# Altium schematic sheet Interfaces.SchDoc(Interfaces)
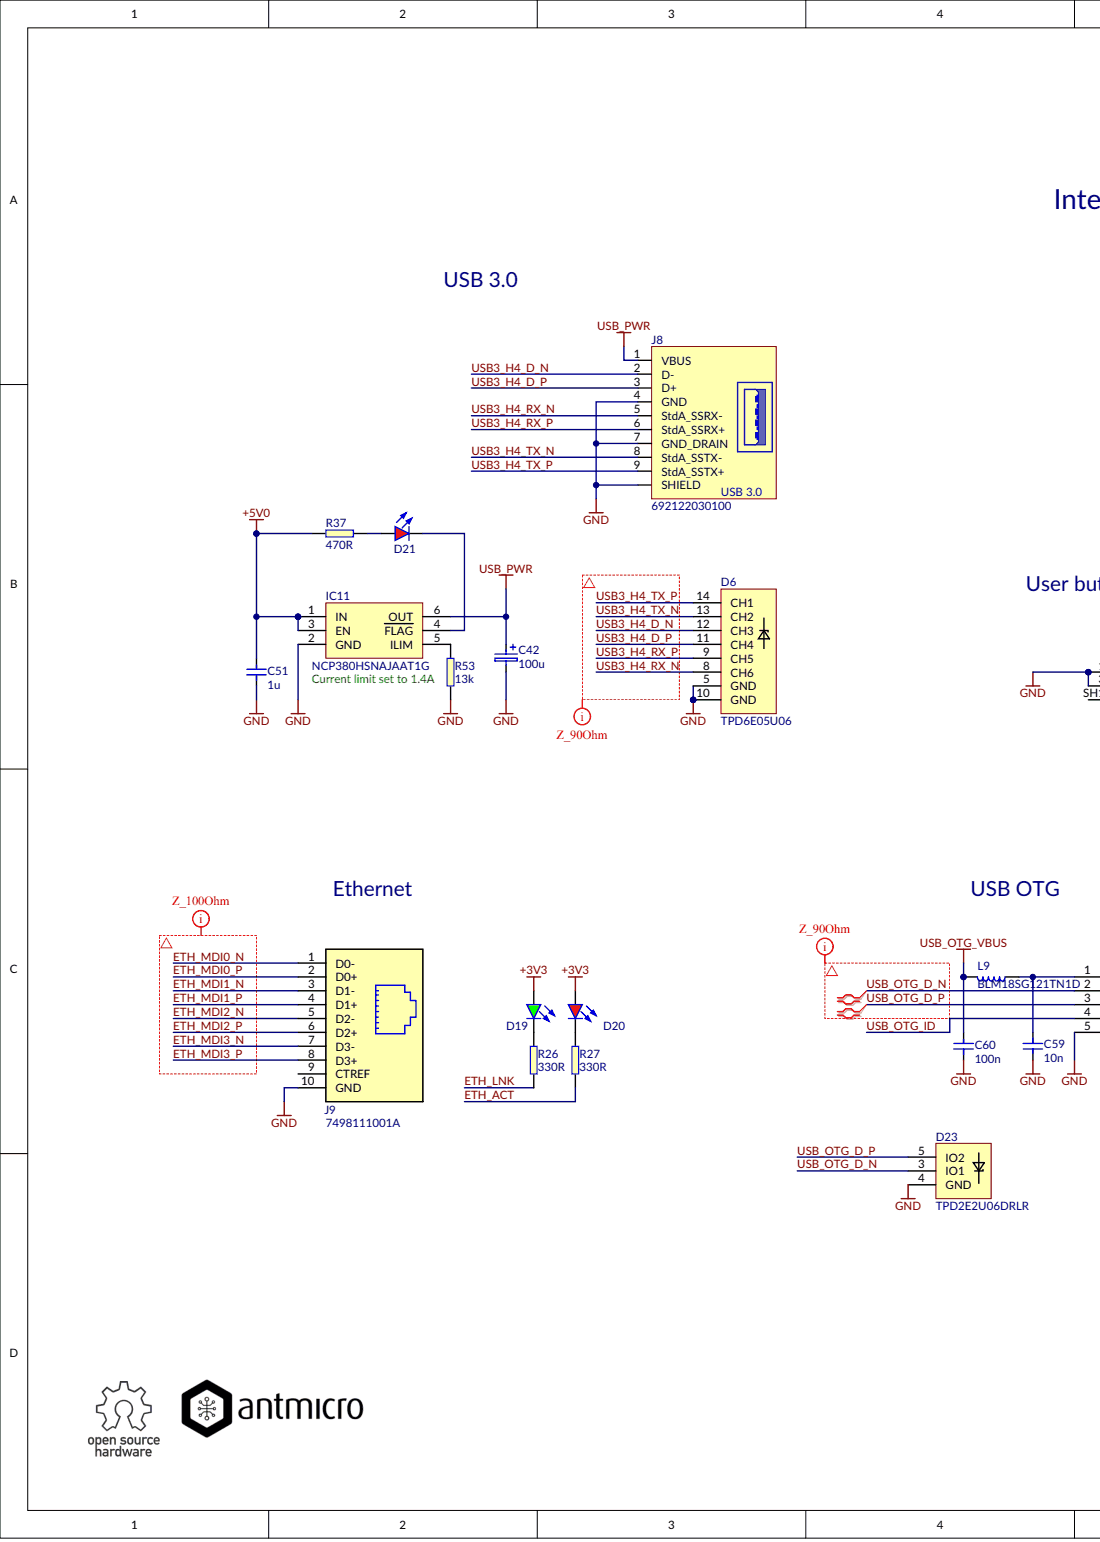
[diagram p0: Interfaces.SchDoc, left]
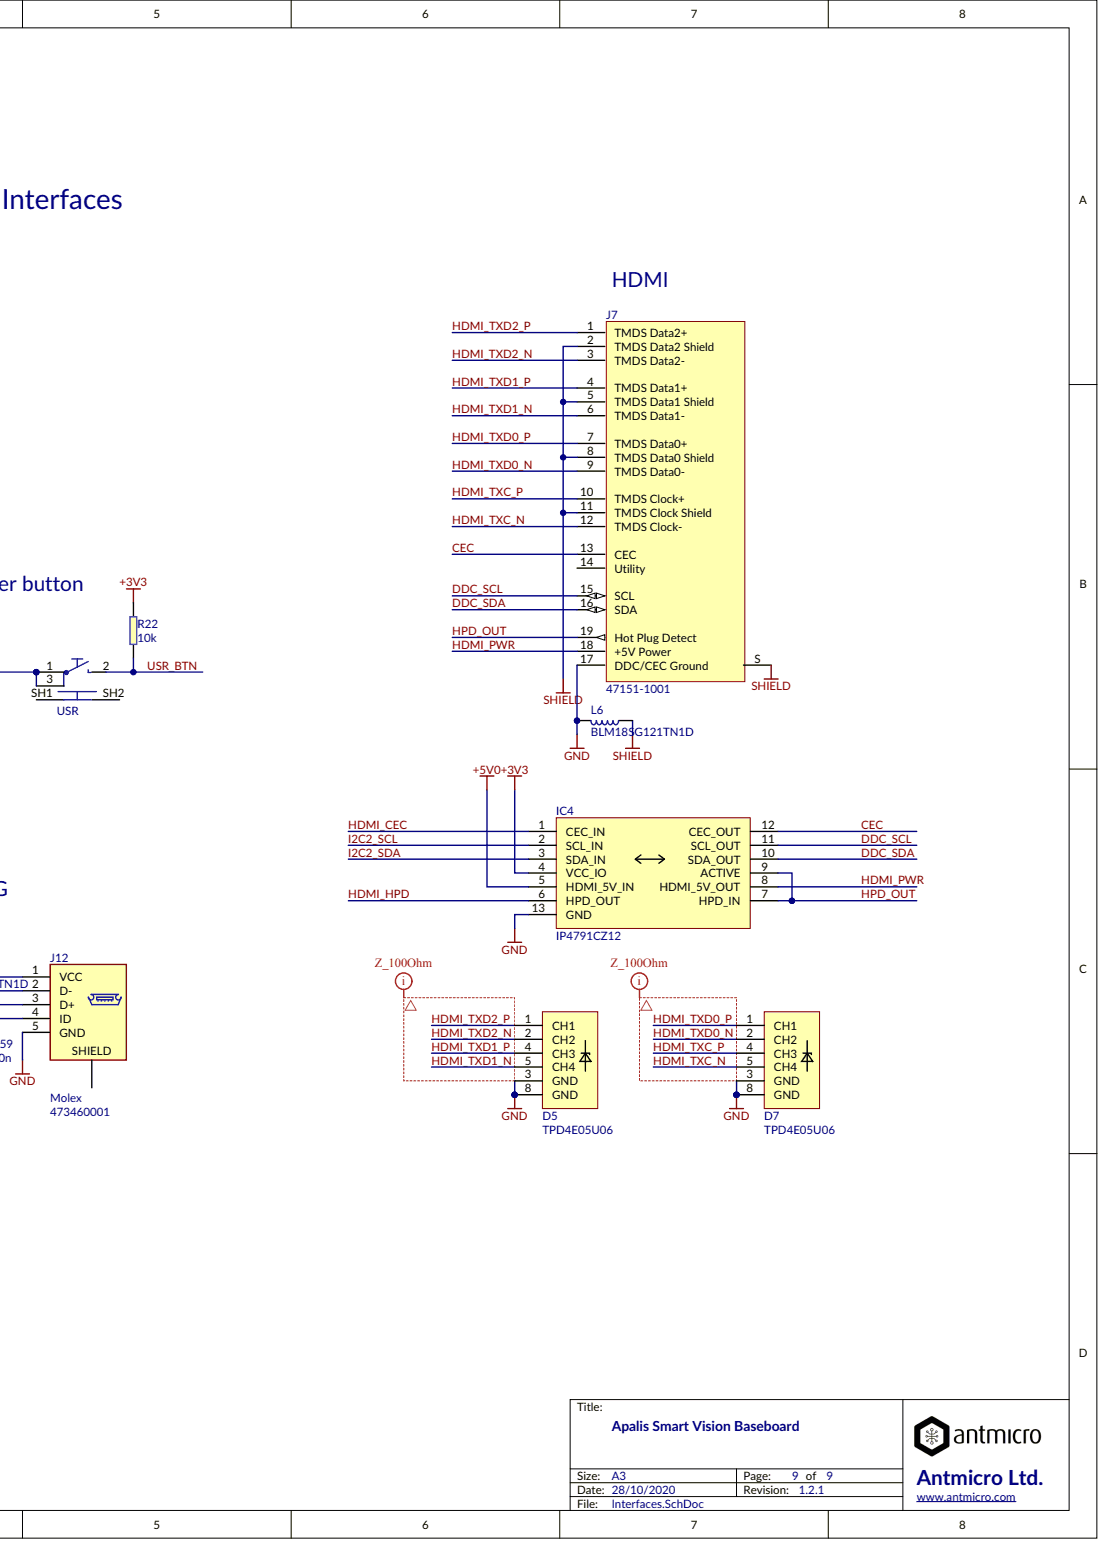
[diagram p1: Interfaces.SchDoc, right]

## Parts
C42  100u
C51  1u
C59  10n
C60  100n
D5  TPD4E05U06
D6  TPD6E05U06
D7  TPD4E05U06
D19  KPJA-2107CKCK  (value not drawn)
D20  KPJA-2107SYCK  (value not drawn)
D21  KPT-1608EC  (value not drawn)
D23  TPD2E2U06DRLR
IC4  IP4791CZ12
IC11  NCP380HSNAJAAT1G
J7  47151-1001
J8  692122030100
J9  7498111001A
J12  Manufacturer: Molex  Part Symbol: 473460001  (hidden comment: Molex 47346-0001)
L6  BLM18SG121TN1D
L9  BLM18SG121TN1D
R22  10k
R26  330R
R27  330R
R37  470R
R53  13k
USR  TL3340AF160QG  (value not drawn)

## Nets
+3V3: D19.A D20.A IC4.4(VCC_IO) R22.2
+5V0: C51.2 IC4.5(HDMI_5V_IN) IC11.1(IN) IC11.3(EN) R37.2
GND: C42.2 C51.1 C59.2 C60.1 D5.3(GND) D5.8(GND) D6.5(GND) D6.10(GND) D7.3(GND) D7.8(GND) D23.4 IC4.13(GND) IC11.2(GND) J7.17 J8.4(GND) J8.7(GND_DRAIN) J8.SHIELD J9.10(GND) J12.5(GND) L6.1 R53.1 USR.1 USR.3
SHIELD: J7.2(TMDS_Data2_Shield) J7.5(TMDS_Data1_Shield) J7.8(TMDS_Data0_Shield) J7.11(TMDS_Clock_Shield) J7.S L6.2
USB_OTG_VBUS: C60.2 L9.1
USB_PWR: C42.1 IC11.6(OUT) J8.1(VBUS)
CEC: IC4.12(CEC_OUT) J7.13(CEC)
DDC_SCL: IC4.11(SCL_OUT) J7.15(SCL)
DDC_SDA: IC4.10(SDA_OUT) J7.16(SDA)
ETH_ACT: R27.2
ETH_LNK: R26.2
ETH_MDI0_N: J9.1(D0-)
ETH_MDI0_P: J9.2(D0+)
ETH_MDI1_N: J9.3(D1-)
ETH_MDI1_P: J9.4(D1+)
ETH_MDI2_N: J9.5(D2-)
ETH_MDI2_P: J9.6(D2+)
ETH_MDI3_N: J9.7(D3-)
ETH_MDI3_P: J9.8(D3+)
HDMI_CEC: IC4.1(CEC_IN)
HDMI_HPD: IC4.6(HPD_OUT)
HDMI_PWR: IC4.8(HDMI_5V_OUT) J7.18(+5V_Power)
HDMI_TXC_N: D7.5(CH4) J7.12(TMDS_Clock-)
HDMI_TXC_P: D7.4(CH3) J7.10(TMDS_Clock+)
HDMI_TXD0_N: D7.2(CH2) J7.9(TMDS_Data0-)
HDMI_TXD0_P: D7.1(CH1) J7.7(TMDS_Data0+)
HDMI_TXD1_N: D5.5(CH4) J7.6(TMDS_Data1-)
HDMI_TXD1_P: D5.4(CH3) J7.4(TMDS_Data1+)
HDMI_TXD2_N: D5.2(CH2) J7.3(TMDS_Data2-)
HDMI_TXD2_P: D5.1(CH1) J7.1(TMDS_Data2+)
HPD_OUT: IC4.7(HPD_IN) IC4.9(ACTIVE) J7.19(Hot_Plug_Detect)
I2C2_SCL: IC4.2(SCL_IN)
I2C2_SDA: IC4.3(SDA_IN)
USB3_H4_D_N: D6.12(CH3) J8.2(D-)
USB3_H4_D_P: D6.11(CH4) J8.3(D+)
USB3_H4_RX_N: D6.8(CH6) J8.5(StdA_SSRX-)
USB3_H4_RX_P: D6.9(CH5) J8.6(StdA_SSRX+)
USB3_H4_TX_N: D6.13(CH2) J8.8(StdA_SSTX-)
USB3_H4_TX_P: D6.14(CH1) J8.9(StdA_SSTX+)
USB_OTG_D_N: D23.3 J12.2(D-)
USB_OTG_D_P: D23.5 J12.3(D+)
USB_OTG_ID: J12.4(ID)
USR_BTN: R22.1 USR.2
NetC59_1: C59.1 J12.1(VCC) L9.2
NetD19_K: D19.K R26.1
NetD20_K: D20.K R27.1
NetD21_A: D21.A R37.1
NetD21_K: D21.K IC11.4(FLAG)
NetIC11_5: IC11.5(ILIM) R53.2
N00141:
N00142:
N00143:
N00144:
N00145:

Not connected: J7 14(Utility); J9 9(CTREF); J12 S; USR SH1 SH2

## Library data of the parts
C42 = (no symbol name): Part Number: C_100u_KEMET_A; Manufacturer: VISHAY; Part Symbol: 298D107X06R3A2T; Value: 100u; MPN: 298D107X06R3A2T
C51 = (no symbol name): Manufacturer: MULTICOMP; Part Number: C_1u_0402; Part Symbol: MC0402F105Z6R3CT; Value: 1u; MPN: MC0402F105Z6R3CT
C59 = (no symbol name): Manufacturer: AVX; Part Number: C_10n_0402; Part Symbol: 04025G103ZAT2A; Value: 10n; MPN: 04025G103ZAT2A
C60 = (no symbol name): Manufacturer: TDK; Part Number: C_100n_0402; Part Symbol: C1005X5R0J104K050BA; Value: 100n; MPN: C1005X5R0J104K050BA
D5 D7 = (no symbol name): Part Number: TPD4E05U06_PASS; Manufacturer: Texas Instruments; Part Symbol: TPD4E05U06DQAR; Value: TPD4E05U06; MPN: TPD4E05U06DQAR
D6 = (no symbol name): Part Number: TPD6E05U06_PASS; Manufacturer: Texas Instruments; Part Symbol: TPD6E05U06RVZR; Value: TPD6E05U06; MPN: TPD6E05U06RVZR
D19 = (no symbol name): Part Number: LED_G; Manufacturer: KINGBRIGHT; Value: KPJA-2107CKCK; Part Symbol: KPJA-2107CKCK; MPN: KPJA-2107CKCK
D20 D21 = (no symbol name): Part Number: LED_R; Manufacturer: KINGBRIGHT
  D20: Value: KPJA-2107SYCK; Part Symbol: KPJA-2107SYCK; MPN: KPJA-2107SYCK
  D21: Value: KPT-1608EC; Part Symbol: KPT-1608EC; MPN: KPT-1608EC
D23 = (no symbol name): Manufacturer: Texas Instruments; Part Number: TPD2E2U06; Value: TPD2E2U06DRLR; Part Symbol: TPD2E2U06DRLR; MPN: TPD2E2U06DRLR
IC4 = (no symbol name): Manufacturer: NXP; Part Number: IP4791CZ12; Value: IP4791CZ12; Part Symbol: IP4791CZ12; MPN: IP4791CZ12
IC11 = (no symbol name): Manufacturer: ON Semiconductor; Part Symbol: NCP380HSNAJAAT1G; Value: NCP380HSNAJAAT1G; Part Number: NCP380HSNAJAAT1G; MPN: NCP380HSNAJAAT1G
J7 = (no symbol name): Part Number: CON-Molex-471511001; Manufacturer: MOLEX; Value: 47151-1001; Part Symbol: 47151-1001; MPN: 47151-1001
J8 = (no symbol name): Manufacturer: WURTH ELEKTRONIK; Part Number: WE-692-122-030-100; Value: 692122030100; Part Symbol: 692122030100; MPN: 692122030100
J9 = (no symbol name): Manufacturer: WURTH ELEKTRONIK; Part Number: WE-749-811-100-1A; Value: 7498111001A; Part Symbol: 7498111001A; MPN: 7498111001A
J12 = (no symbol name): Part Number: CON-Molex-47346-0001; Value: Molex 47346-0001; MPN: 473460001
L6 L9 = (no symbol name): Manufacturer: MURATA; Part Number: BLM18SG121TN1D; Value: BLM18SG121TN1D; Part Symbol: BLM18SG121TN1D; MPN: BLM18SG121TN1D
R22 = (no symbol name): Manufacturer: MULTICOMP; Part Number: R_10k_0402; Part Symbol: MCMR04X1002FTL; Value: 10k; MPN: MCMR04X1002FTL
R26 R27 = (no symbol name): Manufacturer: MULTICOMP; Part Number: R_330R_0402; Part Symbol: MCMR04X3300FTL; Value: 330R; MPN: MCMR04X3300FTL
R37 = (no symbol name): Manufacturer: VISHAY; Part Number: R_470R_0402; Part Symbol: CRCW0402470RFKED.; Value: 470R; MPN: CRCW0402470RFKED.
R53 = (no symbol name): Manufacturer: Panasonic; Part Number: R_13k_0402; Part Symbol: ERJ2GEJ133X; Value: 13k; MPN: ERJ2GEJ133X
USR = (no symbol name): Manufacturer: E-SWITCH; Part Number: TL3340AF160QG; Value: TL3340AF160QG; Part Symbol: TL3340AF160QG; MPN: TL3340AF160QG
